# Altium schematic sheet FEM.SCHDOC(FEM)
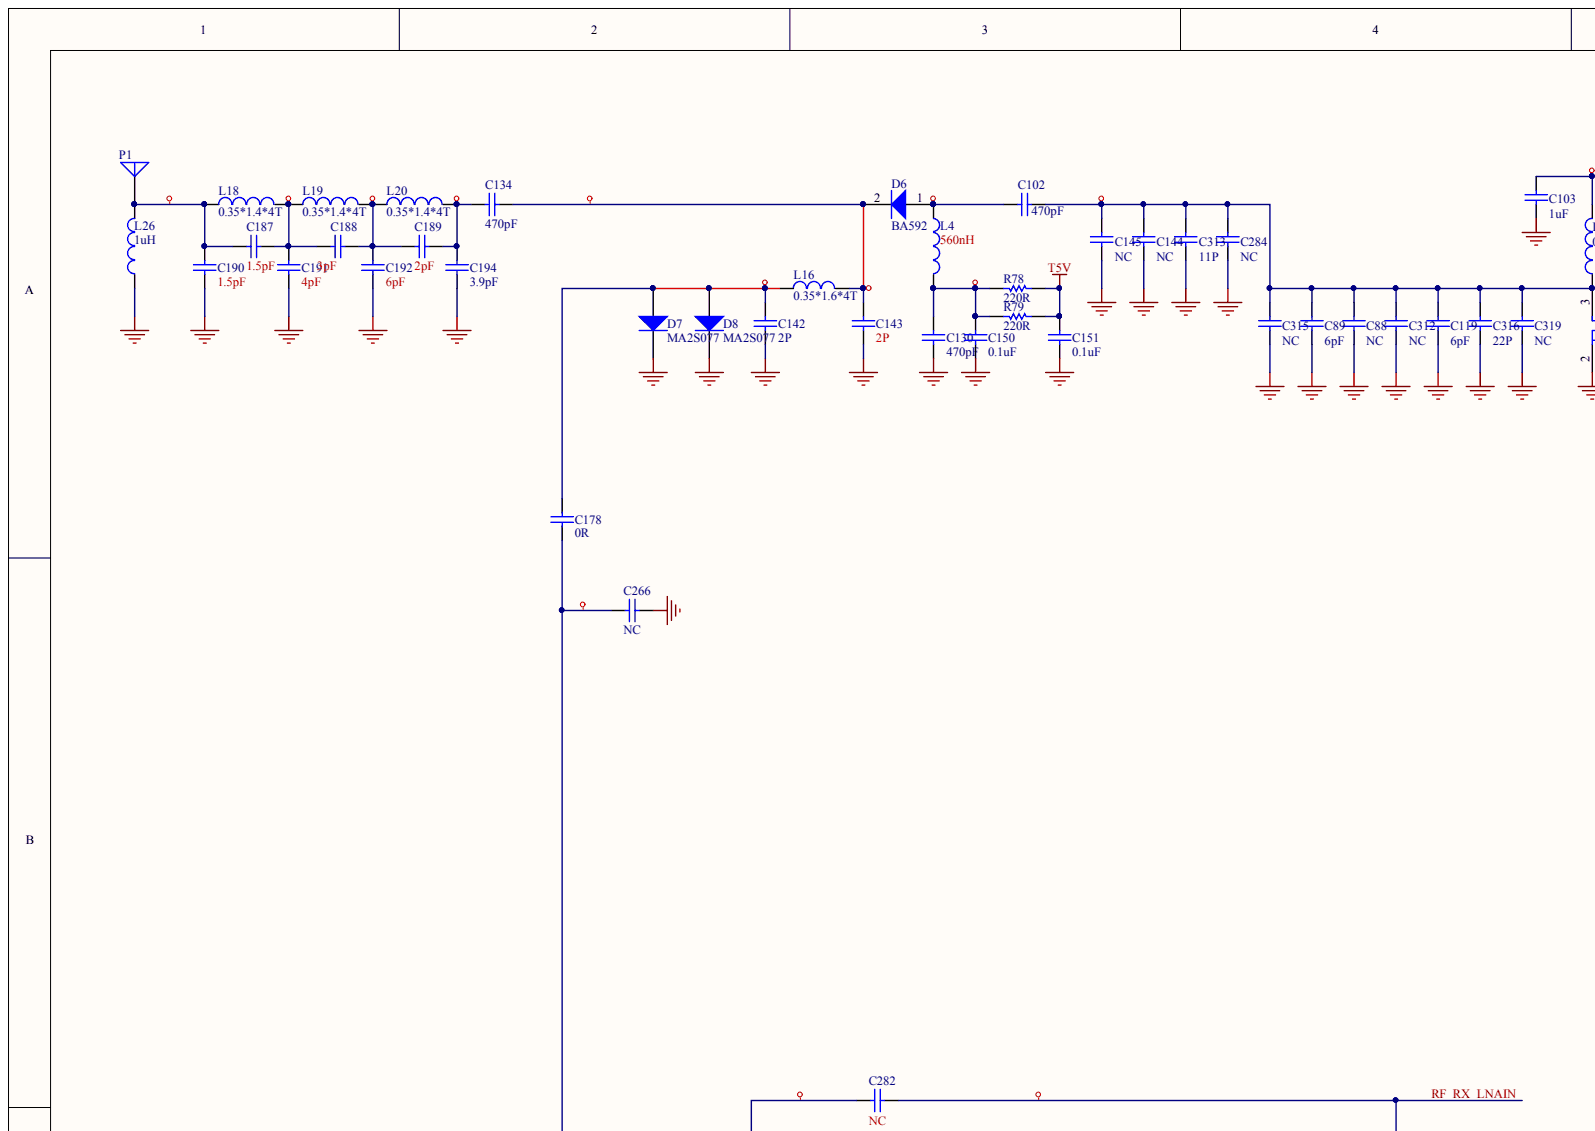
[diagram p0: FEM.SCHDOC, top left]
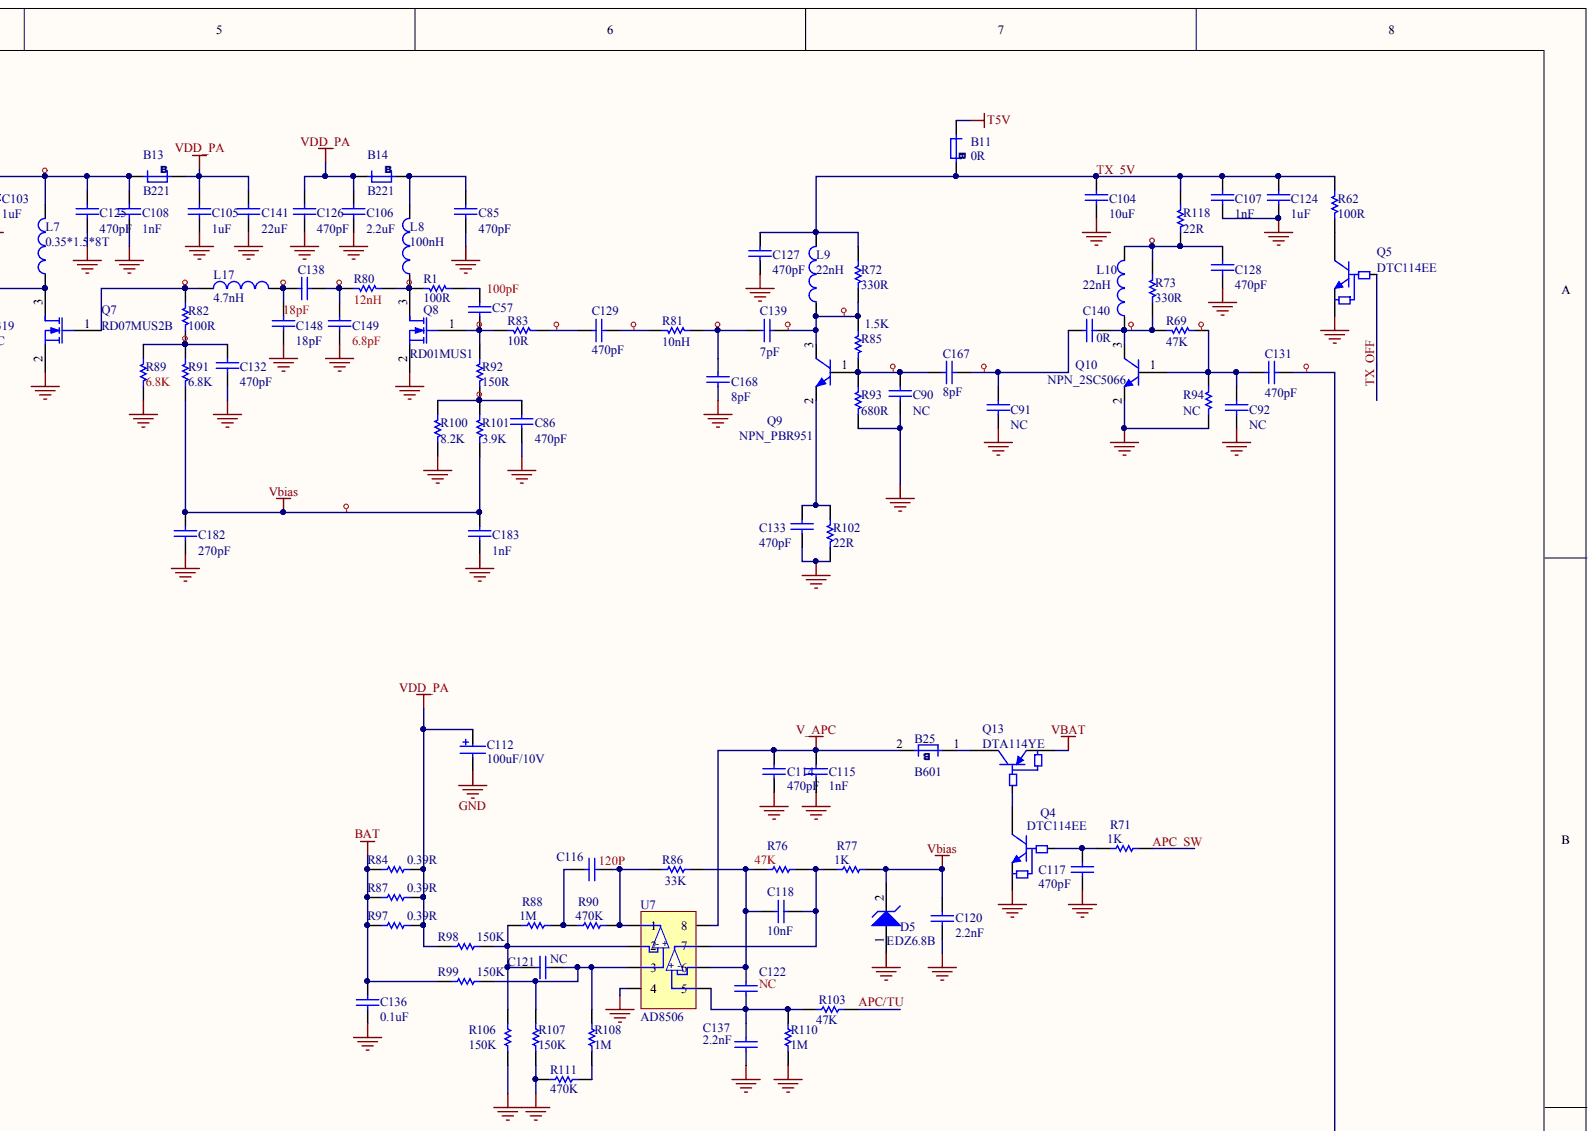
[diagram p1: FEM.SCHDOC, top right]
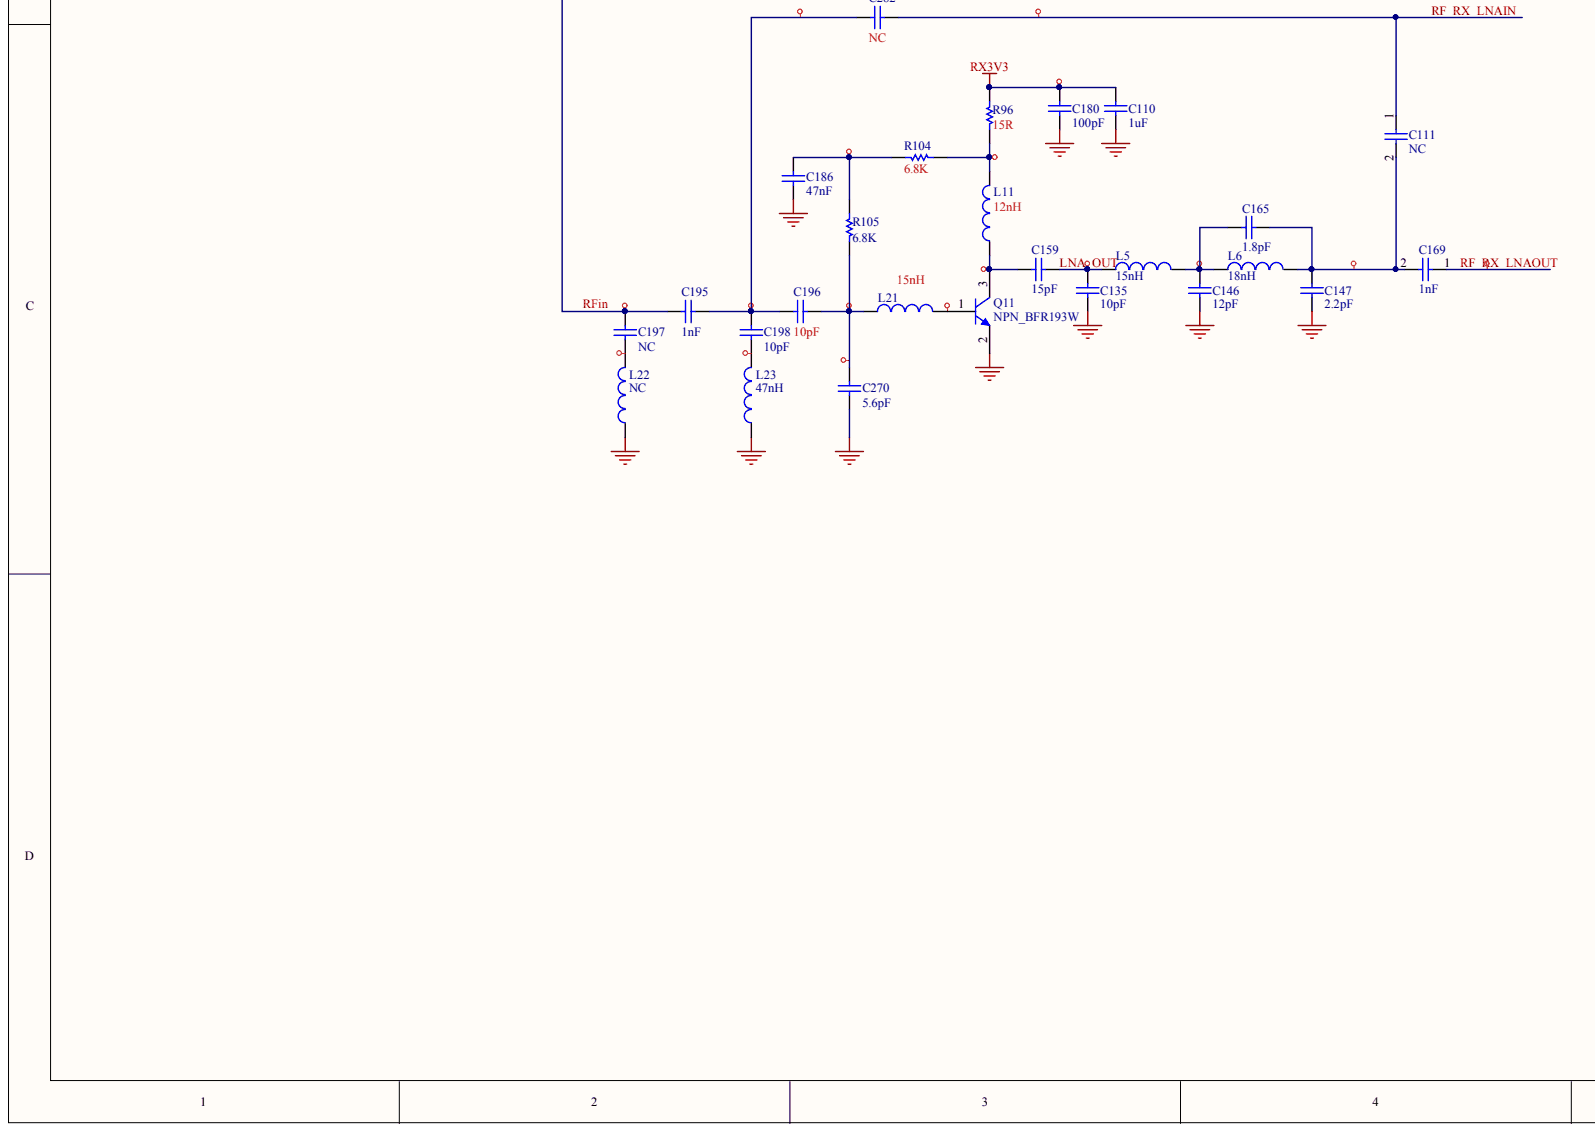
[diagram p2: FEM.SCHDOC, bottom left]
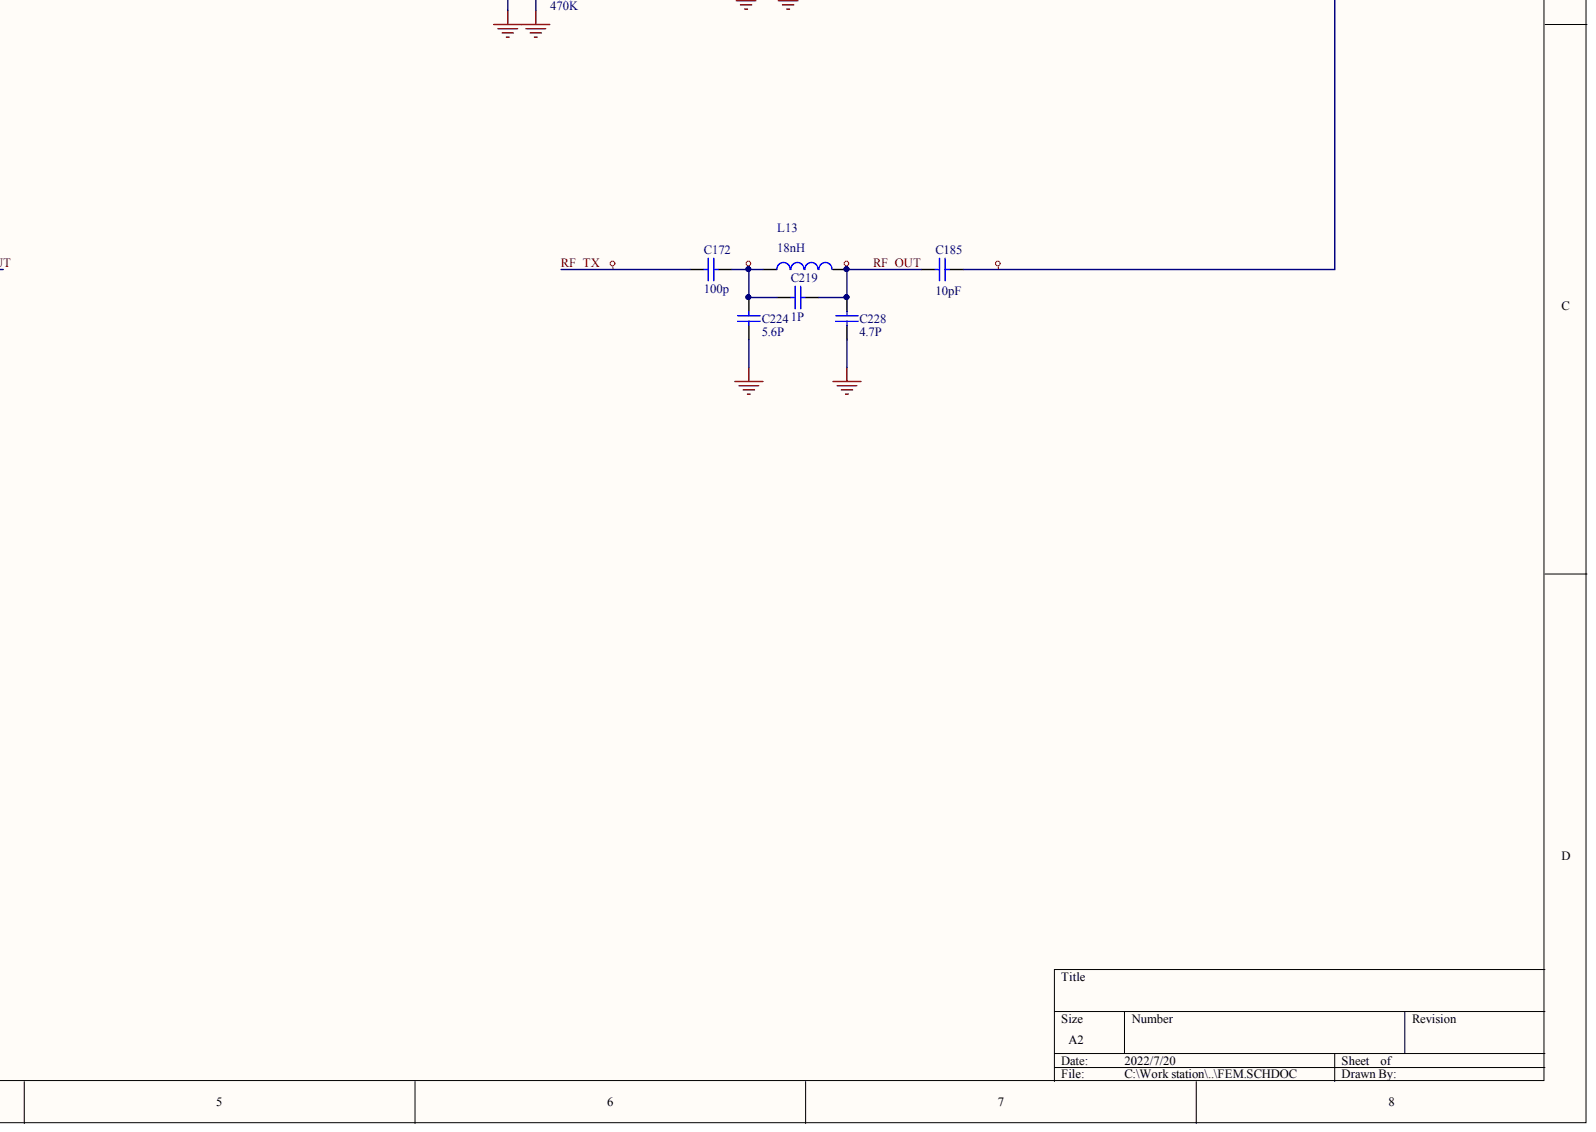
[diagram p3: FEM.SCHDOC, bottom right]

## Parts
B11  0R  [0603_MJ]
B13  B221  [R0805]
B14  B221  [R0805]
B25  B601  [0402]
C57  100pF  [0402]
C85  470pF  [0402]
C86  470pF  [0402]
C88  NC  [MICRO_CAP]
C89  6pF  [MICRO_CAP]
C90  NC  [0402]
C91  NC  [0402]
C92  NC  [0402]
C102  470pF  [0603_MJ]
C103  1uF  [0402]
C104  10uF  [0603_MJ]
C105  1uF  [0402]
C106  2.2uF  [0603_MJ]
C107  1nF  [0402]
C108  1nF  [0402]
C110  1uF  [0402]
C111  NC  [0402]
C112  100uF/10V  [1210_Jun]
C114  470pF  [0402]
C115  1nF  [0402]
C116  120P  [0402]
C117  470pF  [0402]
C118  10nF  [0402]
C119  6pF  [MICRO_CAP]
C120  2.2nF  [0402]
C121  NC  [0402]
C122  NC  [0402]
C124  1uF  [0402]
C125  470pF  [0402]
C126  470pF  [0402]
C127  470pF  [0402]
C128  470pF  [0402]
C129  470pF  [0402]
C130  470pF  [0402]
C131  470pF  [0402]
C132  470pF  [0402]
C133  470pF  [0402]
C134  470pF  [0603_MJ]
C135  10pF  [0402]
C136  0.1uF  [0402]
C137  2.2nF  [0402]
C138  18pF  [0402]
C139  7pF  [0402]
C140  0R  [0402]
C141  22uF  [0805]
C142  2P  [0402]
C143  2P  [0603]
C144  NC  [MICRO_CAP]
C145  NC  [MICRO_CAP]
C146  12pF  [0402]
C147  2.2pF  [0402]
C148  18pF  [0402]
C149  6.8pF  [0402]
C150  0.1uF  value 470pF  [0402]
C151  0.1uF  [0402]
C159  15pF  [0402]
C165  1.8pF  [0402]
C167  8pF  [0402]
C168  8pF  [0402]
C169  1nF  [0402]
C172  100p  [0402]
C178  0R  [0402]
C180  100pF  [0402]
C182  270pF  [0402]
C183  1nF  [0402]
C185  10pF  [0402]
C186  47nF  [0402]
C187  1.5pF  [0603-MINI]
C188  3pF  [0603-MINI]
C189  2pF  [0603-MINI]
C190  1.5pF  [0603-MINI]
C191  4pF  [0603-MINI]
C192  6pF  [0603-MINI]
C194  3.9pF  [0603-MINI]
C195  1nF  [0402]
C196  10pF  [0402]
C197  NC  [0402]
C198  10pF  [0402]
C219  1P  [0402]
C224  5.6P  [0402]
C228  4.7P  [0402]
C266  NC  [0402]
C270  5.6pF  [0402]
C282  NC  [0402]
C284  NC  [MICRO_CAP]
C312  NC  [MICRO_CAP]
C313  11P  [MICRO_CAP]
C315  NC  [MICRO_CAP]
C316  22P  [MICRO_CAP]
C319  NC  [MICRO_CAP]
D5  EDZ6.8B  [HVC131_SMALL]
D6  BA592  [HVC131_LARGE]
D7  MA2S077  [HVC131_SMALL]
D8  MA2S077  [HVC131_SMALL]
L4  560nH  [0805]
L5  15nH  [0603]
L6  18nH  [0603]
L7  0.35*1.5*8T  [8T]
L8  100nH  [0805]
L9  22nH  [0402]
L10  22nH  [0402]
L11  12nH  [0603]
L13  18nH  [0402]
L16  0.35*1.6*4T  [3T]
L17  4.7nH  [0603_MJ]
L18  0.35*1.4*4T  [3T_MJ]
L19  0.35*1.4*4T  [3T_MJ]
L20  0.35*1.4*4T  [3T_MJ]
L21  15nH  [0603]
L22  NC  [0603]
L23  47nH  [0603]
L26  1uH  [1008]
P1  (no value)  [ATT_CON]
Q4  DTC114EE  [DTC114_MJ]
Q5  DTC114EE  [DTC114_MJ]
Q7  RD07MUS2B  [RD07]
Q8  RD01MUS1  [RD01]
Q9  NPN_PBR951  [SOT-23A]
Q10  NPN_2SC5066  [SC70-3_C]
Q11  NPN_BFR193W  [SOT323_BRF193W]
Q13  DTA114YE  [DTC114_MJ]
R1  100R  [0402]
R62  100R  [0402]
R69  47K  [0402]
R71  1K  [0402]
R72  330R  [0402]
R73  330R  [0402]
R76  47K  [0402]
R77  1K  [0402]
R78  220R  [0402]
R79  220R  [0402]
R80  12nH  [0603_MJ]
R81  10nH  [0603_MJ]
R82  100R  [0402]
R83  10R  [0402]
R84  0.39R  [R3216]
R85  1.5K  [0402]
R86  33K  [0402]
R87  0.39R  [R3216]
R88  1M  [0402]
R89  6.8K  [0402]
R90  470K  [0402]
R91  6.8K  [0402]
R92  150R  [0402]
R93  680R  [0402]
R94  NC  [0402]
R96  15R  [0402]
R97  0.39R  [R3216]
R98  150K  [0402]
R99  150K  [0402]
R100  8.2K  [0402]
R101  3.9K  [0402]
R102  22R  [0402]
R103  47K  [0402]
R104  6.8K  [0402]
R105  6.8K  [0402]
R106  150K  [0402]
R107  150K  [0402]
R108  1M  [0402]
R110  1M  [0402]
R111  470K  [0402]
R118  22R  [0402]
U7  AD8506  [MSOP-8]

## Nets
BAT: C136.2 R84.1 R87.1 R97.1 R99.1
GND: C85.1 C86.1 C88.2 C89.2 C90.1 C91.1 C92.1 C103.1 C104.1 C105.1 C106.1 C107.1 C108.1 C110.2 C112.2 C114.2 C115.2 C117.2 C119.2 C120.2 C124.1 C125.1 C126.1 C127.1 C128.1 C130.2 C132.2 C133.2 C135.1 C136.1 C137.2 C141.1 C142.1 C143.1 C144.2 C145.2 C146.1 C147.1 C148.2 C149.2 C150.2 C151.2 C168.2 C180.2 C182.1 C183.1 C186.1 C190.1 C191.1 C192.2 C194.1 C224.2 C228.2 C266.2 C270.1 C284.2 C312.2 C313.2 C315.2 C316.2 C319.2 D5.1 D7.2 D8.2 L22.1 L23.1 L26.1 P1.G0 Q4.3 Q5.3 Q7.2 Q8.2 Q10.2 Q11.2 R89.2 R93.1 R94.1 R100.2 R102.2 R106.1 R107.1 R110.1 R111.2 U7.4
RX3V3: C110.1 C180.1 R96.1
T5V: B11.2 C151.1 R78.1 R79.1
V_APC: B25.2 C114.1 C115.1 U7.8
VBAT: Q13.3
VBIAS: C120.1 C182.2 C183.2 D5.2 R77.2 R91.2 R101.2
VDD_PA: B13.1 B14.2 C105.2 C106.2 C112.1 C126.2 C141.2 R84.2 R87.2 R97.2 R98.1
APC/TU: R103.2
APC_SW: R71.2
LNA_OUT: C135.2 C159.2 L5.1
RF_OUT: C185.1 C219.2 C228.1 L13.2
RF_RX_LNAIN: C111.1 C282.1
RF_RX_LNAOUT: C169.1
RF_TX: C172.2
RFIN: C178.2 C195.1 C197.2 C266.1
TX_5V: B11.1 C104.2 C107.2 C124.2 C127.2 L9.1 R62.1 R72.1 R118.1
TX_OFF: Q5.2
NetB13_2: B13.2 C103.2 C108.2 C125.2 L7.1
NetB14_1: B14.1 C85.2 L8.1
NetB25_1: B25.1 Q13.1
NetC57_1: C57.1 Q8.1 R83.2 R92.1
NetC57_2: C57.2 R1.1
NetC86_2: C86.2 R92.2 R100.1 R101.1
NetC88_1: C88.1 C89.1 C102.1 C119.1 C144.1 C145.1 C284.1 C312.1 C313.1 C315.1 C316.1 C319.1 L7.2 Q7.3
NetC90_2: C90.2 C167.1 Q9.1 R85.2 R93.2
NetC91_2: C91.2 C140.2 C167.2
NetC92_2: C92.2 C131.1 Q10.1 R69.2 R94.2
NetC102_2: C102.2 D6.1 L4.1
NetC111_2: C111.2 C147.2 C165.1 C169.2 L6.2
NetC116_1: C116.1 R86.1 R90.2 U7.1
NetC116_2: C116.2 R88.2 R90.1
NetC117_1: C117.1 Q4.2 R71.1
NetC118_1: C118.1 C122.1 R76.1 R86.2 U7.6
NetC118_2: C118.2 R76.2 R77.1 U7.7
NetC121_1: C121.1 R99.2 R107.2 R108.2 U7.3
NetC121_2: C121.2 R88.1 R98.2 R106.2 U7.2
NetC122_2: C122.2 C137.1 R103.1 R110.2 U7.5
NetC128_2: C128.2 L10.1 R73.2 R118.2
NetC129_1: C129.1 R83.1
NetC129_2: C129.2 R81.1
NetC130_1: C130.1 C150.1 L4.2 R78.2 R79.2
NetC131_2: C131.2 C185.2
NetC132_1: C132.1 R82.2 R89.1 R91.1
NetC133_1: C133.1 Q9.2 R102.1
NetC134_1: C134.1 C189.2 C194.2 L20.2
NetC134_2: C134.2 C143.2 D6.2 L16.2
NetC138_1: C138.1 C148.1 L17.1
NetC138_2: C138.2 C149.1 R80.2
NetC139_1: C139.1 C168.1 R81.2
NetC139_2: C139.2 L9.2 Q9.3 R72.2 R85.1
NetC140_1: C140.1 L10.2 Q10.3 R69.1 R73.1
NetC142_2: C142.2 C178.1 D7.1 D8.1 L16.1
NetC146_2: C146.2 C165.2 L5.2 L6.1
NetC159_1: C159.1 L11.1 Q11.3
NetC172_1: C172.1 C219.1 C224.1 L13.1
NetC186_2: C186.2 R104.1 R105.1
NetC187_1: C187.1 C190.2 L18.1 L26.2 P1.1
NetC187_2: C187.2 C188.2 C191.2 L18.2 L19.1
NetC188_1: C188.1 C189.1 C192.1 L19.2 L20.1
NetC195_2: C195.2 C196.1 C198.2 C282.2
NetC196_2: C196.2 C270.2 L21.1 R105.2
NetC197_1: C197.1 L22.2
NetC198_1: C198.1 L23.2
NetL8_2: L8.2 Q8.3 R1.2 R80.1
NetL11_2: L11.2 R96.2 R104.2
NetL17_2: L17.2 Q7.1 R82.1
NetL21_2: L21.2 Q11.1
NetQ4_1: Q4.1 Q13.2
NetQ5_1: Q5.1 R62.2
NetR108_1: R108.1 R111.1
N00053:

## Library data of the parts
B11 B13 B14 B25 = B: Component Kind: Standard; Library Name: SCH Lib.SCHLIB; Revision: July-2002: Re-released for DXP Platform.
C57 = Cap Semi: Capacitor (Semiconductor SIM Model); Manufacturer: Generic Components; Class: Passive; Component Kind: Standard; Library Name: Miscellaneous Devices.IntLib; Published: 08/06/2000; Signal Integrity: Cap Semi; Simulation: CAP; SubClass: Capacitor; Value: 100pF; Publisher: Altium Hobart Technology Centre
C85 C86 C138 C142 C143 C148 C149 = Cap Semi: Capacitor (Semiconductor SIM Model); Manufacturer: Generic Components; Class: Passive; Value: 100pF; Component Kind: Standard; Library Name: Miscellaneous Devices.IntLib; Published: 08/06/2000; Signal Integrity: Cap Semi; Simulation: CAP; SubClass: Capacitor; Publisher: Altium Hobart Technology Centre
C88 C89 C119 C144 C145 C151 C284 C312 C313 C315 C316 C319 = Cap: Capacitor; Value: 470pF; Component Kind: Standard; Library Name: Miscellaneous Devices.IntLib; Published: 8-Jun-2000; Revision: July-2002: Re-released for DXP Platform.; Signal Integrity: Cap; Simulation: CAP; Publisher: Altium Limited
C90 C91 C103-C105 C108 C124 C126 C127 C134 C141 C178 C187 C189 C194 C266 = Cap: Capacitor; Value: 2.2uF; Component Kind: Standard; Library Name: Miscellaneous Devices.IntLib; Published: 8-Jun-2000; Revision: July-2002: Re-released for DXP Platform.; Signal Integrity: Cap; Simulation: CAP; Publisher: Altium Limited
C92 C107 C114-C118 C120-C122 C136 C137 C191 = Cap: Capacitor; Value: 5.6pF; Component Kind: Standard; Library Name: Miscellaneous Devices.IntLib; Published: 8-Jun-2000; Revision: July-2002: Re-released for DXP Platform.; Signal Integrity: Cap; Simulation: CAP; Publisher: Altium Limited
C102 C106 C130 C132 C150 = Cap: Capacitor; Component Kind: Standard; Library Name: Miscellaneous Devices.IntLib; Published: 8-Jun-2000; Revision: July-2002: Re-released for DXP Platform.; Signal Integrity: Cap; Simulation: CAP; Publisher: Altium Limited
  C102 C130 C132: Value: 470pF
  C106: Value: 2.2uF
C110 C111 C135 C146 C147 C159 C165 C169 C180 C186 C195-C198 C270 C282 = Cap: Capacitor; Manufacturer: Generic Components; Class: Passive; Component Kind: Standard; Library Name: Miscellaneous Devices.IntLib; Published: 08/06/2000; Signal Integrity: Cap; Simulation: CAP; SubClass: Capacitor; Publisher: Altium Hobart Technology Centre
C112 = CAP_0805: Component Kind: Standard; Library Name: MYSCHLIB.SCHLIB
C125 C182 C183 = Cap: Capacitor; Value: 120pF; Component Kind: Standard; Library Name: Miscellaneous Devices.IntLib; Published: 8-Jun-2000; Revision: July-2002: Re-released for DXP Platform.; Signal Integrity: Cap; Simulation: CAP; Publisher: Altium Limited
C128 C129 C131 C133 C139 C168 C185 C188 C190 C192 = Cap: Capacitor; Value: 360pF; Component Kind: Standard; Library Name: Miscellaneous Devices.IntLib; Published: 8-Jun-2000; Revision: July-2002: Re-released for DXP Platform.; Signal Integrity: Cap; Simulation: CAP; Publisher: Altium Limited
C140 C167 = Cap: Capacitor; Value: 1uF; Component Kind: Standard; Library Name: Miscellaneous Devices.IntLib; Published: 8-Jun-2000; Revision: July-2002: Re-released for DXP Platform.; Signal Integrity: Cap; Simulation: CAP; Publisher: Altium Limited
C172 C219 C224 C228 = Cap: Capacitor; Manufacturer: Generic Components; Class: Passive; Value: 100pF; Component Kind: Standard; Library Name: Miscellaneous Devices.IntLib; Published: 08/06/2000; Signal Integrity: Cap; Simulation: CAP; SubClass: Capacitor; Publisher: Altium Hobart Technology Centre
D5 = D Zener: Zener Diode; Manufacturer: Generic Components; Class: Discrete; SubClass: Diode - Zener; Component Kind: Standard; Library Name: Miscellaneous Devices.IntLib; Published: 08/06/2000; Signal Integrity: D Zener; Simulation: ZENER; Publisher: Altium Hobart Technology Centre
D6-D8 = Diode: Default Diode; Manufacturer: Generic Components; Class: Discrete; SubClass: Diode - Switching; Component Kind: Standard; Library Name: Miscellaneous Devices.IntLib; Published: 08/06/2000; Signal Integrity: Diode; Simulation: DIODE; Publisher: Altium Hobart Technology Centre
L4 = Inductor: Value: 4.7nH; Component Kind: Standard; Description: Inductor; Library Name: Miscellaneous Devices.IntLib; Published: 8-Jun-2000; Revision: July-2002: Re-released for DXP Platform.; Signal Integrity: Inductor; Simulation: INDUCTOR; Publisher: Altium Limited
L5 L6 L11 L21-L23 = Inductor: Manufacturer: Generic Components; Class: Passive; Component Kind: Standard; Description: Inductor; Library Name: Miscellaneous Devices.IntLib; Published: 08/06/2000; Signal Integrity: Inductor; Simulation: INDUCTOR; SubClass: Inductor; Publisher: Altium Hobart Technology Centre
L7 L8 L17 = Inductor: Value: 7T5; Component Kind: Standard; Description: Inductor; Library Name: Miscellaneous Devices.IntLib; Published: 8-Jun-2000; Revision: July-2002: Re-released for DXP Platform.; Signal Integrity: Inductor; Simulation: INDUCTOR; Publisher: Altium Limited
L9 = Inductor: Value: 82nH; Component Kind: Standard; Description: Inductor; Library Name: Miscellaneous Devices.IntLib; Published: 8-Jun-2000; Revision: July-2002: Re-released for DXP Platform.; Signal Integrity: Inductor; Simulation: INDUCTOR; Publisher: Altium Limited
L10 L13 L18-L20 L26 = Inductor: Value: 47nH; Component Kind: Standard; Description: Inductor; Library Name: Miscellaneous Devices.IntLib; Published: 8-Jun-2000; Revision: July-2002: Re-released for DXP Platform.; Signal Integrity: Inductor; Simulation: INDUCTOR; Publisher: Altium Limited
L16 = INDUCTOR: Component Kind: Standard; Library Name: SCH Lib.SCHLIB
P1 = Antenna: Generic Antenna; Manufacturer: Generic Components; Component Kind: Standard; Library Name: Miscellaneous Devices.IntLib; Published: 1999
Q4 Q5 = DTC114: Component Kind: Standard; Library Name: SCH Lib.SCHLIB
Q7 Q8 = MOSFET-N: N-Channel MOSFET; Manufacturer: Generic Components; Class: Discrete; SubClass: MOSFET - Low Power; Component Kind: Standard; Library Name: Miscellaneous Devices.IntLib; Published: 08/06/2000; Simulation: NMOS; Publisher: Altium Hobart Technology Centre
Q9 = NPN_PBR951: NPN; Code_JEDEC: TO-226-AA; Component Kind: Standard; LatestRevisionDate: 17-Jul-2002; LatestRevisionNote: Re-released for DXP Platform.; PackageReference: TO-226; Published: 8-Jun-2000; Signal Integrity: NPN; Simulation: NPN; Library Name: zySCHLIB_Z.SCHLIB; Publisher: Altium Limited
Q11 = NPN_BFR193W: NPN Bipolar Transistor; Manufacturer: Generic Components; Class: Discrete; SubClass: BJT - Low Power; Component Kind: Standard; Published: 08/06/2000; Library Name: zySCHLIB_Z.SCHLIB; Publisher: Altium Hobart Technology Centre
Q13 = DTA114: Component Kind: Standard; Library Name: SCH Lib.SCHLIB
R1 R72 R80-R83 R85 R89 R91 R92 R96 R100-R102 R104 R105 = Res1: Resistor; Value: 1K; Component Kind: Standard; Library Name: Miscellaneous Devices.IntLib; Published: 8-Jun-2000; Revision: July-2002: Re-released for DXP Platform.; Signal Integrity: Res; Simulation: RESISTOR; Publisher: Altium Limited
R62 R69 R76 R84 R86-R88 R90 R93 R97-R99 R103 R106-R108 R110 R111 R118 = Res Semi: Semiconductor Resistor; Manufacturer: Generic Components; Class: Passive; Value: 1K; Component Kind: Standard; Library Name: Miscellaneous Devices.IntLib; Published: 08/06/2000; Signal Integrity: Res Semi; Simulation: RES; SubClass: Resistor; Publisher: Altium Hobart Technology Centre
R71 R77 = Res Semi: Semiconductor Resistor; Manufacturer: Generic Components; Class: Passive; Component Kind: Standard; Library Name: Miscellaneous Devices.IntLib; Published: 08/06/2000; Signal Integrity: Res Semi; Simulation: RES; SubClass: Resistor; Value: 1K; Publisher: Altium Hobart Technology Centre
R73 R94 = Res1: Resistor; Value: 0; Component Kind: Standard; Library Name: Miscellaneous Devices.IntLib; Published: 8-Jun-2000; Revision: July-2002: Re-released for DXP Platform.; Signal Integrity: Res; Simulation: RESISTOR; Publisher: Altium Limited
R78 R79 = Res1: Resistor; Value: 1; Component Kind: Standard; Library Name: Miscellaneous Devices.IntLib; Published: 8-Jun-2000; Revision: July-2002: Re-released for DXP Platform.; Signal Integrity: Res; Simulation: RESISTOR; Publisher: Altium Limited
U7 = NJM2904: Component Kind: Standard; Library Name: SCH Lib.SCHLIB
Q10 = NPN_2SC5066: Code_JEDEC: TO-226-AA; Component Kind: Standard; LatestRevisionDate: 17-Jul-2002; LatestRevisionNote: Re-released for DXP Platform.; PackageReference: TO-226; Published: 8-Jun-2000; Signal Integrity: NPN; Simulation: NPN; Library Name: zySCHLIB_Z.SCHLIB; Publisher: Altium Limited
